# Altium schematic sheet PWR_Manage.SchDoc
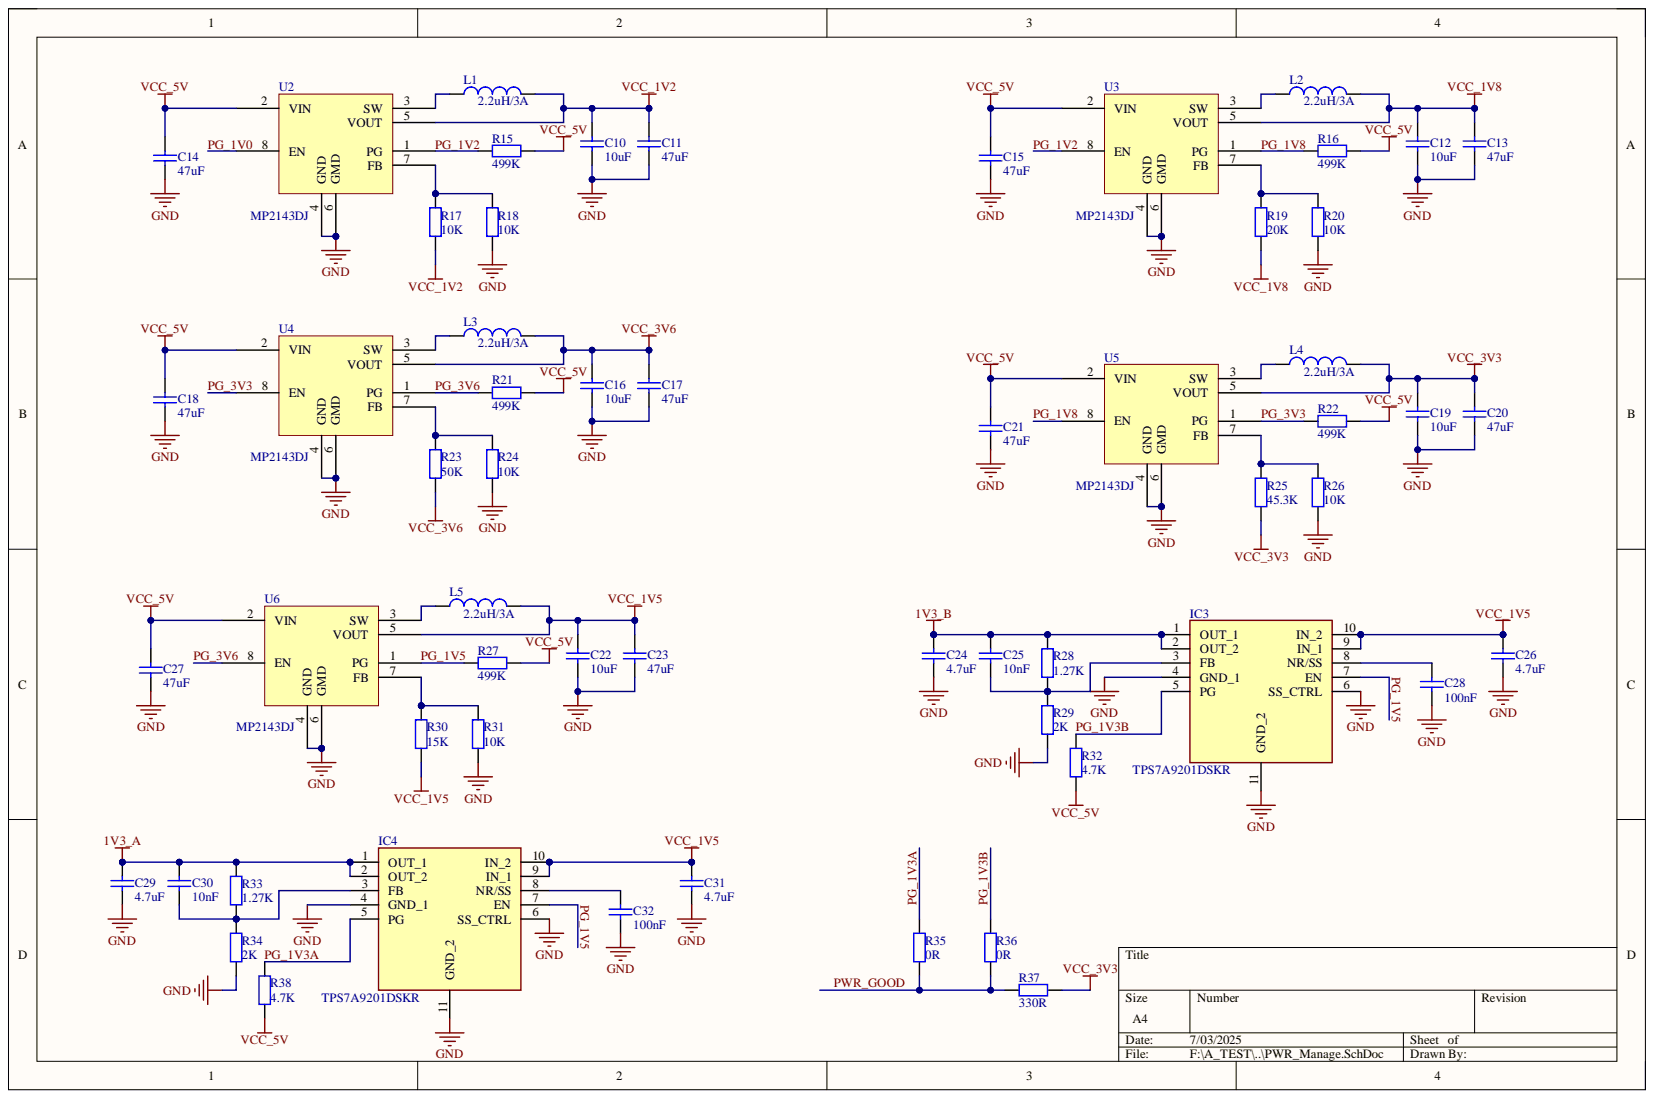
[diagram p0: PWR_Manage.SchDoc]

## Parts
C10  10uF  [C 0603_L]
C11  47uF  [C 0805_L]
C12  10uF  [C 0603_L]
C13  47uF  [C 0805_L]
C14  47uF  [C 0805_L]
C15  47uF  [C 0805_L]
C16  10uF  [C 0603_L]
C17  47uF  [C 0805_L]
C18  47uF  [C 0805_L]
C19  10uF  [C 0603_L]
C20  47uF  [C 0805_L]
C21  47uF  [C 0805_L]
C22  10uF  [C 0603_L]
C23  47uF  [C 0805_L]
C24  4.7uF  [C 0402_L]
C25  10nF  [C 0402_L]
C26  4.7uF  [C 0402_L]
C27  47uF  [C 0805_L]
C28  100nF  [C 0402_L]
C29  4.7uF  [C 0402_L]
C30  10nF  [C 0402_L]
C31  4.7uF  [C 0402_L]
C32  100nF  [C 0402_L]
IC3  TPS7A9201DSKR  [SON50P250X250X80-11N-D]
IC4  TPS7A9201DSKR  [SON50P250X250X80-11N-D]
L1  2.2uH/3A  [NR2520]
L2  2.2uH/3A  [NR2520]
L3  2.2uH/3A  [NR2520]
L4  2.2uH/3A  [NR2520]
L5  2.2uH/3A  [NR2520]
R15  499K  [R 0402_L]
R16  499K  [R 0402_L]
R17  10K  [R 0402_L]
R18  10K  [R 0402_L]
R19  20K  [R 0402_L]
R20  10K  [R 0402_L]
R21  499K  [R 0402_L]
R22  499K  [R 0402_L]
R23  50K  [R 0402_L]
R24  10K  [R 0402_L]
R25  45.3K  [R 0402_L]
R26  10K  [R 0402_L]
R27  499K  [R 0402_L]
R28  1.27K  [R 0402_L]
R29  2K  [R 0402_L]
R30  15K  [R 0402_L]
R31  10K  [R 0402_L]
R32  4.7K  [R 0402_L]
R33  1.27K  [R 0402_L]
R34  2K  [R 0402_L]
R35  0R  [R 0402_L]
R36  0R  [R 0402_L]
R37  330R  [R 0402_L]
R38  4.7K  [R 0402_L]
U2  MP2143DJ  [SOP65P280X100-8N]
U3  MP2143DJ  [SOP65P280X100-8N]
U4  MP2143DJ  [SOP65P280X100-8N]
U5  MP2143DJ  [SOP65P280X100-8N]
U6  MP2143DJ  [SOP65P280X100-8N]

## Nets
1V3_A: C29.2 C30.2 IC4.1(OUT_1) IC4.2(OUT_2) R33.2
1V3_B: C24.2 C25.2 IC3.1(OUT_1) IC3.2(OUT_2) R28.2
GND: C10.1 C11.1 C12.1 C13.1 C14.1 C15.1 C16.1 C17.1 C18.1 C19.1 C20.1 C21.1 C22.1 C23.1 C24.1 C26.1 C27.1 C28.1 C29.1 C31.1 C32.1 IC3.4(GND_1) IC3.6(SS_CTRL) IC3.11(GND_2) IC4.4(GND_1) IC4.6(SS_CTRL) IC4.11(GND_2) R18.1 R20.1 R24.1 R26.1 R29.1 R31.1 R34.1 U2.4(GND) U2.6(GMD) U3.4(GND) U3.6(GMD) U4.4(GND) U4.6(GMD) U5.4(GND) U5.6(GMD) U6.4(GND) U6.6(GMD)
VCC_1V2: C10.2 C11.2 L1.2 R17.2 U2.5(VOUT)
VCC_1V5: C22.2 C23.2 C26.2 C31.2 IC3.9(IN_1) IC3.10(IN_2) IC4.9(IN_1) IC4.10(IN_2) L5.2 R30.2 U6.5(VOUT)
VCC_1V8: C12.2 C13.2 L2.2 R19.2 U3.5(VOUT)
VCC_3V3: C19.2 C20.2 L4.2 R25.2 R37.1 U5.5(VOUT)
VCC_3V6: C16.2 C17.2 L3.2 R23.2 U4.5(VOUT)
VCC_5V: C14.2 C15.2 C18.2 C21.2 C27.2 R15.2 R16.2 R21.2 R22.2 R27.2 R32.1 R38.1 U2.2(VIN) U3.2(VIN) U4.2(VIN) U5.2(VIN) U6.2(VIN)
PG_1V0: U2.8(EN)
PG_1V2: R15.1 U2.1(PG) U3.8(EN)
PG_1V3A: IC4.5(PG) R35.1 R38.2
PG_1V3B: IC3.5(PG) R32.2 R36.1
PG_1V5: IC3.7(EN) IC4.7(EN) R27.1 U6.1(PG)
PG_1V8: R16.1 U3.1(PG) U5.8(EN)
PG_3V3: R22.1 U4.8(EN) U5.1(PG)
PG_3V6: R21.1 U4.1(PG) U6.8(EN)
PWR_GOOD: R35.2 R36.2 R37.2
NetC25_1: C25.1 IC3.3(FB) R28.1 R29.2
NetC28_2: C28.2 IC3.8(NR/SS)
NetC30_1: C30.1 IC4.3(FB) R33.1 R34.2
NetC32_2: C32.2 IC4.8(NR/SS)
NetL1_1: L1.1 U2.3(SW)
NetL2_1: L2.1 U3.3(SW)
NetL3_1: L3.1 U4.3(SW)
NetL4_1: L4.1 U5.3(SW)
NetL5_1: L5.1 U6.3(SW)
NetR17_1: R17.1 R18.2 U2.7(FB)
NetR19_1: R19.1 R20.2 U3.7(FB)
NetR23_1: R23.1 R24.2 U4.7(FB)
NetR25_1: R25.1 R26.2 U5.7(FB)
NetR30_1: R30.1 R31.2 U6.7(FB)

## Library data of the parts
C10-C23 C27 = Cap1: Component Kind: Standard; Library Name: 零散元件库.SCHLIB
  C10 C12 C16 C19 C22: value: 10uF
  C11 C13-C15 C17 C18 C20 C21 C23 C27: value: 47uF
C24-C26 C28-C32 = Cap: 贴片电容; Component Kind: Standard; Library Name: A_Schlib.SchLib
  C24 C26 C29 C31: value: 4.7uF
  C25 C30: value: 10nF
  C28 C32: value: 100nF
IC3 IC4 = TPS7A9101DSKR: Integrated Circuit; Manufacturer_Name: Texas Instruments; Arrow Part Number: TPS7A9101DSKR; Arrow Price/Stock: https://www.arrow.com/en/products/tps7a9101dskr/texas-instruments?region=nac; Component Kind: Standard; Datasheet Link: http://www.ti.com/lit/ds/symlink/tps7a91.pdf; Height: 0.8mm; Manufacturer_Part_Number: TPS7A9101DSKR; Mouser Part Number: 595-TPS7A9101DSKR; Mouser Price/Stock: https://www.mouser.co.uk/ProductDetail/Texas-Instruments/TPS7A9101DSKR?qs=34RfhUjJmKdov7HQNWp7rg%3D%3D
L1-L5 = INDUCTOR: Component Kind: Standard; Library Name: 电感-SCH.Lib
R15-R26 R30 R31 = Res: Component Kind: Standard; Library Name: 零散元件库.SCHLIB
  R15 R16 R21 R22: value: 499K
  R17 R18 R20 R24 R26 R31: value: 10K
  R19: value: 20K
  R23: value: 50K
  R25: value: 45.3K
  R30: value: 15K
R27-R29 R32-R38 = Res: 通用; Component Kind: Standard; Library Name: A_Schlib.SchLib
  R27: value: 499K
  R28 R33: value: 1.27K
  R29 R34: value: 2K
  R32 R38: value: 4.7K
  R35 R36: value: 0R
  R37: value: 330R
U2-U6 = Component_1_2: Component Kind: Standard; Library Name: ZYNQ_开发板.IntLib
